# Altium schematic sheet 02 FPGA_CFG.SchDoc
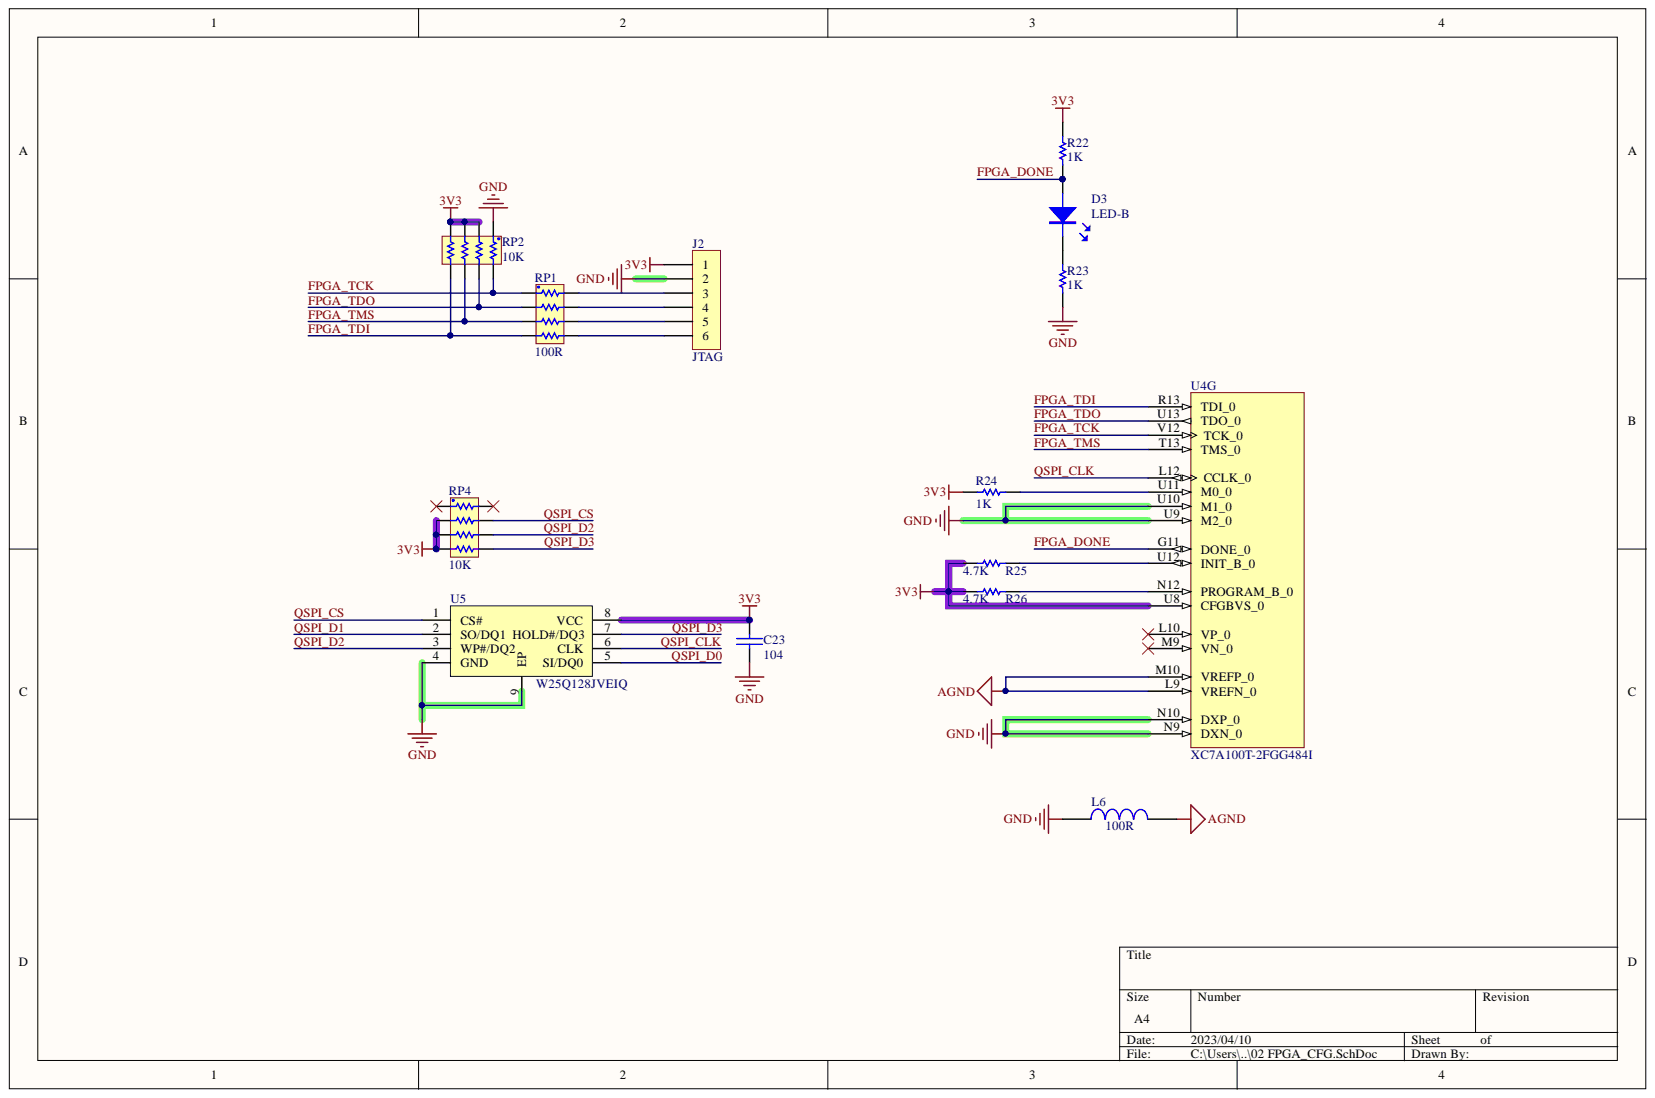
[diagram p0: 02 FPGA_CFG.SchDoc]

## Parts
C23  104  [0402C]
D3  LED-B  [LED-0603B]
J2  JTAG  [Pin HDR1X6/2.54mm-S]
L6  100R  [0603L]
R22  1K  [0402R]
R23  1K  [0402R]
R24  1K  [0402R]
R25  4.7K  [0402R]
R26  4.7K  [0402R]
RP1  100R  [贴片排阻]
RP2  10K  [贴片排阻]
RP4  10K  [贴片排阻]
U4  XC7A100T-2FGG484I  [FGG484]  (part G)
U5  W25Q128JVEIQ  [WSON-8_L6.0-W8.0-P1.27-BL-EP]

## Nets
3V3: C23.2 J2.1 R22.2 R24.1 R25.1 R26.1 RP2.2 RP2.3 RP2.4 RP4.2 RP4.3 RP4.4 U4.U8(CFGBVS_0) U5.8(VCC)
AGND: L6.2 U4.L9(VREFN_0) U4.M10(VREFP_0)
GND: C23.1 J2.2 L6.1 R23.1 RP2.1 U4.N9(DXN_0) U4.N10(DXP_0) U4.U9(M2_0) U4.U10(M1_0) U5.4(GND) U5.9(EP)
FPGA_DONE: D3.A R22.1 U4.G11(DONE_0)
FPGA_TCK: RP1.1 RP2.8 U4.V12(TCK_0)
FPGA_TDI: RP1.4 RP2.5 U4.R13(TDI_0)
FPGA_TDO: RP1.2 RP2.7 U4.U13(TDO_0)
FPGA_TMS: RP1.3 RP2.6 U4.T13(TMS_0)
QSPI_CLK: U4.L12(CCLK_0) U5.6(CLK)
QSPI_CS: RP4.7 U5.1(CS#)
QSPI_D0: U5.5(SI/DQ0)
QSPI_D1: U5.2(SO/DQ1)
QSPI_D2: RP4.6 U5.3(WP#/DQ2)
QSPI_D3: RP4.5 U5.7(HOLD#/DQ3)
NetD3_K: D3.K R23.2
NetJ2_3: J2.3 RP1.8
NetJ2_4: J2.4 RP1.7
NetJ2_5: J2.5 RP1.6
NetJ2_6: J2.6 RP1.5
NetR24_2: R24.2 U4.U11(M0_0)
NetR25_2: R25.2 U4.U12(INIT_B_0)
NetR26_2: R26.2 U4.N12(PROGRAM_B_0)

Not connected: RP4 1 8; U4 L10(VP_0) M9(VN_0)

## Library data of the parts
C23 = CAP: 无极性电容; Component Kind: Standard; Library Name: 电容.IntLib
D3 = LED: Component Kind: Standard; Library Name: LED.IntLib
J2 = Pin HDR1X6: Component Kind: Standard; Library Name: 排针.IntLib
L6 = INDUCTOR: Component Kind: Standard; Description: INDUCTOR; Library Name: 电感.IntLib
R22-R26 = RES: Component Kind: Standard; Library Name: 电阻.IntLib
RP1 RP2 RP4 = SMT_RES_PACK8: Component Kind: Standard; Library Name: 电阻.IntLib
U4 = XC7A100T-2FGG484I: Artix-7 FPGA, 285 User I/Os, 4 GTP, 484-Ball BGA, Speed Grade 2, Industrial Grade, Pb-Free; Manufacturer: Xilinx; Block RAM Blocks (18Kb): 270; Block RAM Blocks (36Kb): 135; Block RAM Blocks (Max Kb): 4860; CLBs (Slices): 15850; Clock Mgmt Tiles (CMTs): 6; DSP48E1 Slices: 240; Logic Cells: 101440; Max User I/O: 300; Speed Grade: -2; CLBs (Max Distributed RAM Kb): 1188; Code_JEDEC: MS-034-AAJ-1; Component Kind: Standard; ComponentLink1Description: Manufacturer URL; ComponentLink1URL: http://www.xilinx.com/; ComponentLink2Description: Datasheet; ComponentLink2URL: http://www.xilinx.com/support/documentation/data_sheets/ds180_7Series_Overview.pdf; ComponentLink3Description: Package Specification; ComponentLink3URL: http://www.xilinx.com/support/documentation/user_guides/ug475_7Series_Pkg_Pinout.pdf; ComponentLink4Description: DC and Switching Characteristics; ComponentLink4URL: http://www.xilinx.com/support/documentation/data_sheets/ds181_Artix_7_Data_Sheet.pdf; ComponentLink5Description: Package File; ComponentLink5URL: http://www.xilinx.com/support/packagefiles/a7packages/xc7a100tfgg484pkg.txt; ComponentLink6Description: Packaging and Pinout; ComponentLink6URL: http://www.xilinx.com/support/documentation/user_guides/ug475_7Series_Pkg_Pinout.pdf; DatasheetVersion: v1.12; Generic Part Number: XC7A100T; Library Name: Xilinx Artix-7.IntLib; Mounting Technology: Surface Mount; NexusDeviceID: XC7A100T-2FGG484I; PackageDescription: Wire-Bond Fine-Pitch BGA, Body 23 x 23 mm, 1.0 mm Pitch; PackageReference: FGG484; PackageVersion: Rev 1.8; PartNumber: XC7A100T-2FGG484I; RoHS: Pb-Free; Supplier 1: Digi-Key; Supplier Part Number 1: 122-1879-ND
U5 = 25_SERIES_FLASH_WSON: Component Kind: Standard; Library Name: 未分类IC.IntLib
